# Altium schematic sheet Microcontroller.SchDoc
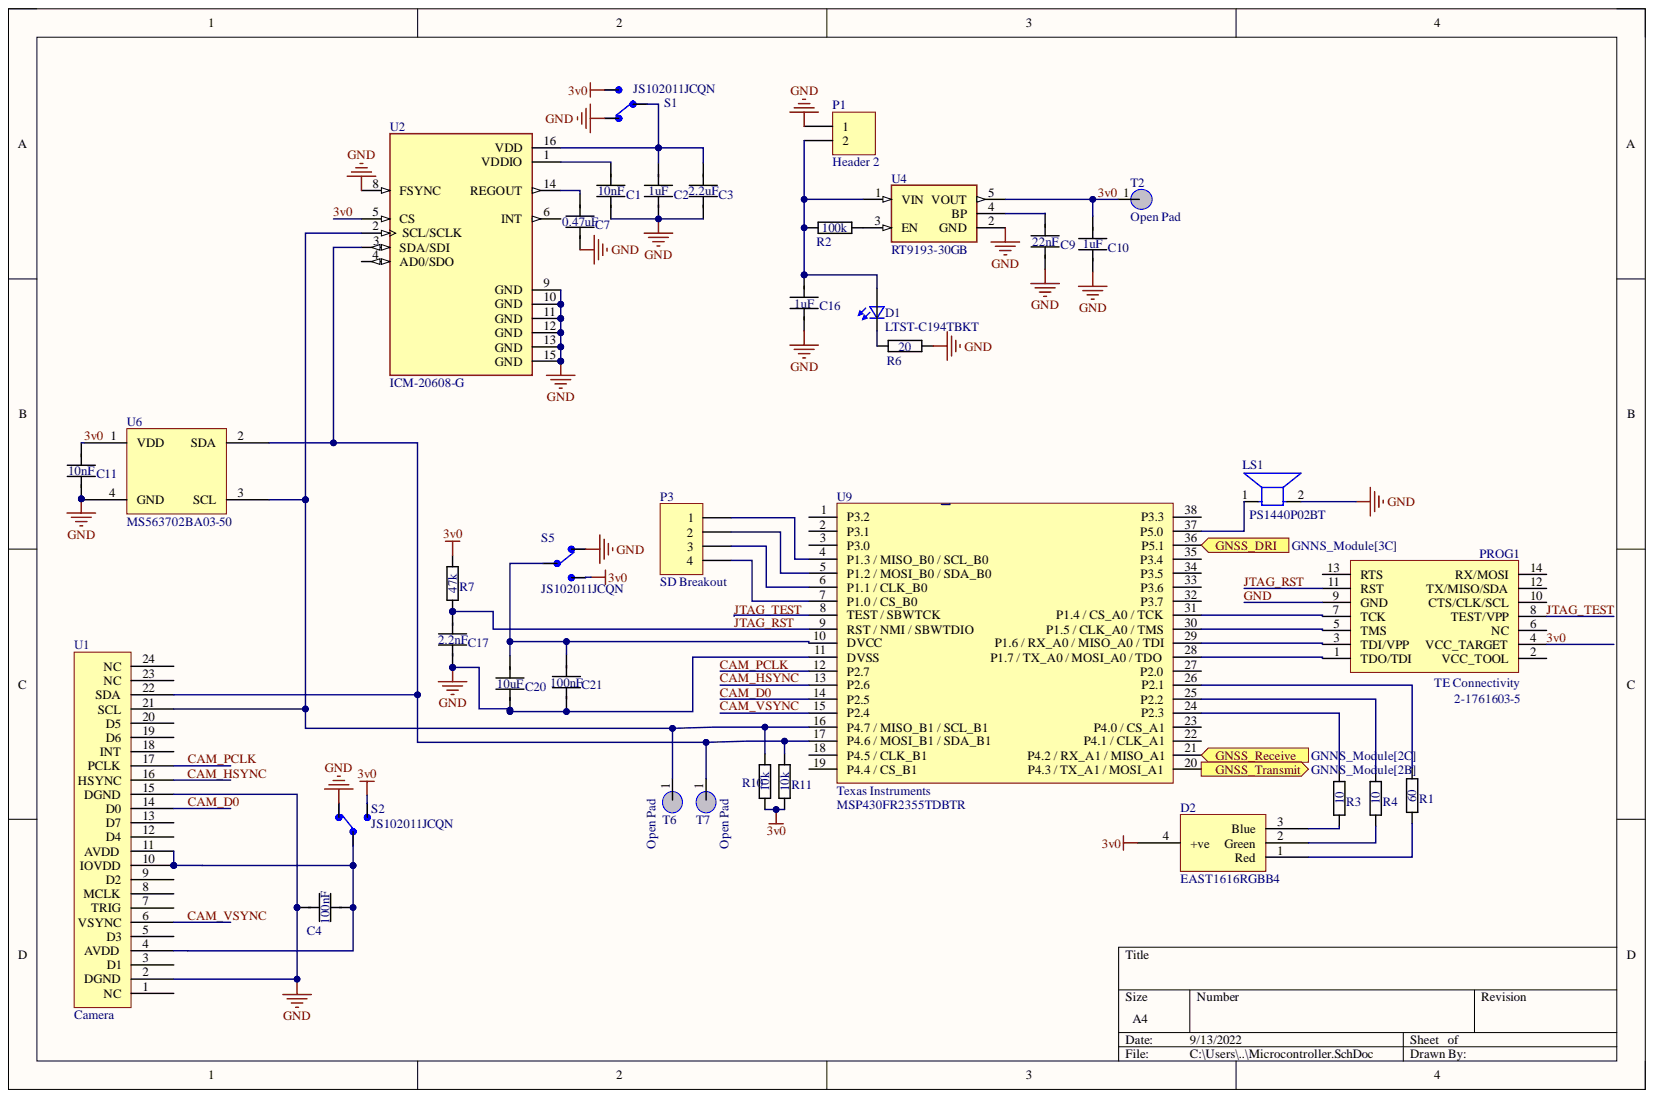
[diagram p0: Microcontroller.SchDoc]

## Parts
C1  value 10nF  [0603 (Capacitor)]  (hidden comment: C-n-010.000-5-0603)
C2  value 1uF  [0603 (Capacitor)]  (hidden comment: C-n-001.000-5-0603)
C3  C-n-001.000-5-0603  [0603 (Capacitor)]  (value not drawn)
C4  value 100nF  [0603 (Capacitor)]  (hidden comment: C-n-100.000-10-0603)
C7  C-n-010.000-5-0603  [0603 (Capacitor)]  (value not drawn)
C9  value 22nF  [0603 (Capacitor)]  (hidden comment: C-n-001.000-5-0603)
C10  value 1uF  [0603 (Capacitor)]  (hidden comment: C-n-001.000-5-0603)
C11  value 10nF  [0603 (Capacitor)]  (hidden comment: C-n-010.000-5-0603)
C16  value 1uF  [0603 (Capacitor)]  (hidden comment: C-n-001.000-5-0603)
C17  C-n-002.200-5-0603  [0603 (Capacitor)]  (value not drawn)
C20  value 10uF  [0603 (Capacitor)]  (hidden comment: C-n-001.000-5-0603)
C21  C-n-100.000-10-0603  [0603 (Capacitor)]  (value not drawn)
D1  LTST-C194TBKT  [0603]
D2  EAST1616RGBB4  [EAST1616RGBB4 - duplicate1]
LS1  PS1440P02BT  [BUZZER_PS1440P02BT]
P1  Header 2  [Battery Connector- 620102131822]
P3  SD Breakout  [HDR1X4]
PROG1  Manufacturer: TE Connectivity  Manufucturer PartNo: 2-1761603-5  [TE 2-1761603-5]  (hidden comment: MSP430 Programming Header)
R1  value 60  [0603 (Resistor)]  (hidden comment: R-k-010.000-1-0603)
R2  value 100k  [0603 (Resistor)]  (hidden comment: R-k-100.000-1-0603)
R3  value 10  [0603 (Resistor)]  (hidden comment: R-k-010.000-1-0603)
R4  value 10  [0603 (Resistor)]  (hidden comment: R-k-010.000-1-0603)
R6  value 20  [0603 (Resistor)]  (hidden comment: R-k-001.500-1-0603)
R7  value 47k  [0603 (Resistor)]  (hidden comment: R-k-047.000-1-0603)
R10  value 10k  [0603 (Resistor)]  (hidden comment: R-k-010.000-1-0603)
R11  value 10k  [0603 (Resistor)]  (hidden comment: R-k-010.000-1-0603)
S1  JS102011JCQN  [SW_JS102011JCQN]
S2  JS102011JCQN  [SW_JS102011JCQN]
S5  JS102011JCQN  [SW_JS102011JCQN]
T2  Open Pad  [Open Pad]
T6  Open Pad  [Open Pad]
T7  Open Pad  [Open Pad]
U1  Camera  [SFV24R-2STE1HLF]
U2  ICM-20608-G  [ICM-20608-G]
U4  RT9193-30GB  [RT9193-33GB]
U6  MS563702BA03-50  [MS5837-30BA]
U9  Manufacturer: Texas Instruments  Manufacturer Part Number: MSP430FR2355TDBTR  [MSP430FR2355 38-TFSOP]  (hidden comment: MSP430FR2355 38-TFSOP)

## Ports
GNSS_DRI, GNSS_Receive, GNSS_Transmit

## Nets
3v0: C10.1 C11.1 D2.4(+ve) PROG1.4(VCC_TARGET) R7.1 R10.2 R11.2 S1.3 S2.3 S5.3 T2.1 U2.5(CS) U4.5(VOUT) U6.1(VDD)
CAM_D0: U1.14(D0) U9.14(P2.5)
CAM_HSYNC: U1.16(HSYNC) U9.13(P2.6)
CAM_PCLK: U1.17(PCLK) U9.12(P2.7)
CAM_VSYNC: U1.6(VSYNC) U9.15(P2.4)
GND: C1.2 C2.2 C3.2 C4.1 C7.2 C9.2 C10.2 C11.2 C16.2 C17.2 C20.2 C21.2 LS1.2 P1.1 PROG1.9(GND) R6.1 S1.1 S2.1 S5.1 U1.2(DGND) U1.15(DGND) U2.8(FSYNC) U2.9(GND) U2.10(GND) U2.11(GND) U2.12(GND) U2.13(GND) U2.15(GND) U4.2(GND) U6.4(GND) U9.11(DVSS)
GNSS_DRI: U9.36(P5.1) ; port GNSS_DRI
GNSS_Receive: U9.21(P4.2_/_RX_A1_/_MISO_A1) ; port GNSS_Receive
GNSS_Transmit: U9.20(P4.3_/_TX_A1_/_MOSI_A1) ; port GNSS_Transmit
JTAG_RST: C17.1 PROG1.11(RST) R7.2 U9.9(RST_/_NMI_/_SBWTDIO)
JTAG_TEST: PROG1.8(TEST/VPP) U9.8(TEST_/_SBWTCK)
NetC1_1: C1.1 U2.1(VDDIO)
NetC2_1: C2.1 C3.1 S1.2 U2.16(VDD)
NetC4_2: C4.2 S2.2 U1.4(AVDD) U1.10(IOVDD) U1.11(AVDD)
NetC7_1: C7.1 U2.14(REGOUT)
NetC9_1: C9.1 U4.4(BP)
NetC16_1: C16.1 D1.2 P1.2 R2.2 U4.1(VIN)
NetC20_1: C20.1 C21.1 S5.2 U9.10(DVCC)
NetD1_1: D1.1 R6.2
NetD2_1: D2.1(Red) R1.2
NetD2_2: D2.2(Green) R4.2
NetD2_3: D2.3(Blue) R3.2
NetLS1_1: LS1.1 U9.37(P5.0)
NetP3_1: P3.1 U9.4(P1.3_/_MISO_B0_/_SCL_B0)
NetP3_2: P3.2 U9.5(P1.2_/_MOSI_B0_/_SDA_B0)
NetP3_3: P3.3 U9.6(P1.1_/_CLK_B0)
NetP3_4: P3.4 U9.7(P1.0_/_CS_B0)
NetPROG1_1: PROG1.1(TDO/TDI) U9.28
NetPROG1_3: PROG1.3(TDI/VPP) U9.29(P1.6_/_RX_A0_/_MISO_A0_/_TDI)
NetPROG1_5: PROG1.5(TMS) U9.30(P1.5_/_CLK_A0_/_TMS)
NetPROG1_7: PROG1.7(TCK) U9.31(P1.4_/_CS_A0_/_TCK)
NetR1_1: R1.1 U9.26(P2.1)
NetR2_1: R2.1 U4.3(EN)
NetR3_1: R3.1 U9.24(P2.3)
NetR4_1: R4.1 U9.25(P2.2)
NetR10_1: R10.1 T6.1 U1.21(SCL) U2.2(SCL/SCLK) U6.3(SCL) U9.16(P4.7_/_MISO_B1_/_SCL_B1)
NetR11_1: R11.1 T7.1 U1.22(SDA) U2.3(SDA/SDI) U6.2(SDA) U9.17(P4.6_/_MOSI_B1_/_SDA_B1)

Not connected: PROG1 2(VCC_TOOL) 6(NC) 10(CTS/CLK/SCL) 12(TX/MISO/SDA) 13(RTS) 14(RX/MOSI); U1 1(NC) 3(D1) 5(D3) 7(TRIG) 8(MCLK) 9(D2) 12(D4) 13(D7) 18(INT) 19(D6) 20(D5) 23(NC) 24(NC); U2 4(AD0/SDO) 6(INT); U9 1(P3.2) 2(P3.1) 3(P3.0) 18(P4.5_/_CLK_B1) 19(P4.4_/_CS_B1) 22(P4.1_/_CLK_A1) 23(P4.0_/_CS_A1) 27(P2.0) 32(P3.7) 33(P3.6) 34(P3.5) 35(P3.4) 38(P3.3)

## Library data of the parts
C1 C11 = C-n-010.000-5-0603: Capacitor 10nF 0603 +/-5%; Manufacturer: Murata; Manufacturer PartNo: GRM1885C1H103JA01D; Case/Package: 0603; Component Kind: Standard; Library Name: Passives.SchLib; Tolerance: +/-5%
C2 C10 C16 = C-n-001.000-5-0603: Capacitor 1uF 0603 +/-5%; Manufacturer: Murata; Manufacturer PartNo: GCM1885C2A102JA16D; Case/Package: 0603; Component Kind: Standard; Library Name: Passives.SchLib; Tolerance: +/-5%
C3 = C-n-001.000-5-0603: Capacitor 2,2uF 0603 +/-5%; Manufacturer: Murata; Manufacturer PartNo: GCM1885C2A102JA16D; Value: 2.2uF; Case/Package: 0603; Component Kind: Standard; Library Name: Passives.SchLib; Tolerance: +/-5%
C4 C21 = C-n-100.000-10-0603: Capacitor 100nF 0603 +/-10%; Manufacturer: Murata; Manufacturer PartNo: GRM188R72A104KA35D; Case/Package: 0603; Component Kind: Standard; Library Name: Passives.SchLib; Tolerance: +/-10%
  C21: Value: 100nF
C7 = C-n-010.000-5-0603: Capacitor 0.47uF 0603 +/-5%; Manufacturer: Murata; Manufacturer PartNo: GRM1885C1H103JA01D; Case/Package: 0603; Component Kind: Standard; Library Name: Passives.SchLib; Tolerance: +/-5%; Value: 0.47uF
C9 = C-n-001.000-5-0603: Capacitor 22nF 0603 +/-5%; Manufacturer: Murata; Manufacturer PartNo: GCM1885C2A102JA16D; Case/Package: 0603; Component Kind: Standard; Library Name: Passives.SchLib; Tolerance: +/-5%
C17 = C-n-001.000-5-0603: Capacitor 2.2nF 0603 +/-5%; Manufacturer: Murata; Manufacturer PartNo: GCM1885C2A102JA16D; Case/Package: 0603; Component Kind: Standard; Library Name: Passives.SchLib; Tolerance: +/-5%; Value: 2.2nF
C20 = C-n-001.000-5-0603: Capacitor 10uF 0603 +/-5%; Manufacturer: Murata; Manufacturer PartNo: GCM1885C2A102JA16D; Case/Package: 0603; Component Kind: Standard; Library Name: Passives.SchLib; Tolerance: +/-5%
D1 = LTST-C194TBKT: Blue 468nm LED Indication - Discrete 3.3V 0603 _1608 Metric_; MF: Lite-On Inc.; Component Kind: Standard; Digi-Key_Part_Number: 160-1837-1-ND; Digi-Key_Purchase_URL: https://www.digikey.com/product-detail/en/lite-on-inc/LTST-C194TBKT/160-1837-1-ND/2356236?utm_source=snapeda&utm_medium=aggregator&utm_campaign=symbol; Library Name: SnapEDAsch.SchLib; MP: LTST-C194TBKT; Package: 0603 Lite-On; SnapEDA_Link: https://www.snapeda.com/parts/LTST-C194TBKT/Lite-On%20Inc./view-part/426592/?ref=snap
LS1 = PS1440P02BT: Buzzers Transducer, Externally Driven Piezo 3V 4kHz 75dB @ 3V, 10cm Through Hole PC Pins; MF: TDK; Component Kind: Standard; Digi-Key_Part_Number: 445-5242-1-ND; Digi-Key_Purchase_URL: https://www.digikey.com/product-detail/en/tdk-corporation/PS1440P02BT/445-5242-1-ND/2236832?utm_source=snapeda&utm_medium=aggregator&utm_campaign=symbol; Library Name: Schlib.SchLib; MP: PS1440P02BT; Package: SOP-8 TDK; SnapEDA_Link: https://www.snapeda.com/parts/PS1440P02BT/TDK/view-part/295705/?ref=snap
P1 = Header 2: Header, 2-Pin; Component Kind: Standard; LatestRevisionDate: 17-Jul-2002; LatestRevisionNote: Re-released for DXP Platform.; Library Name: Miscellaneous Connectors.SchLib; Signal Integrity: Connector; Publisher: Altium Limited
P3 = Header 4: Header, 4-Pin; Component Kind: Standard; LatestRevisionDate: 17-Jul-2002; LatestRevisionNote: Re-released for DXP Platform.; Library Name: Miscellaneous Connectors.IntLib; Signal Integrity: Connector; Publisher: Altium Limited
PROG1 = MSP430 Programming Header: 14 Pin JTAG Header for MSP430 Debug Probe; Component Kind: Standard; Library Name: Ti MSP430.SchLib
R1 = R-k-010.000-1-0603: Resistor 60 0603 +/-1%; Manufacturer: Vishay Dale; Manufacturer PartNo: CRCW060310K0FKEAHP; Case/Package: 0603; Component Kind: Standard; Library Name: Passives.SchLib; Tolerance: +/-1%
R2 = R-k-100.000-1-0603: Resistor 100k 0603 +/-1%; Manufacturer: Vishay Dale; Manufacturer PartNo: CRCW0603100KFKEAHP; Case/Package: 0603; Component Kind: Standard; Library Name: Passives.SchLib; Tolerance: +/-1%
R3 R4 = R-k-010.000-1-0603: Resistor 10 0603 +/-1%; Manufacturer: Vishay Dale; Manufacturer PartNo: CRCW060310K0FKEAHP; Case/Package: 0603; Component Kind: Standard; Library Name: Passives.SchLib; Tolerance: +/-1%
R6 = R-k-001.500-1-0603: Resistor 20 0603 +/-1%; Manufacturer: Vishay Dale; Manufacturer PartNo: CRCW06031K50FKEAHP; Case/Package: 0603; Component Kind: Standard; Library Name: Passives.SchLib; Tolerance: +/-1%
R7 = R-k-068.000-1-0603: Resistor 47k 0603 +/-1%; Manufacturer: Vishay Dale; Manufacturer PartNo: CRCW060368K0FKEAHP; Case/Package: 0603; Component Kind: Standard; Library Name: Passives.SchLib; Tolerance: +/-1%
R10 R11 = R-k-010.000-1-0603: Resistor 10k 0603 +/-1%; Manufacturer: Vishay Dale; Manufacturer PartNo: CRCW060310K0FKEAHP; Case/Package: 0603; Component Kind: Standard; Library Name: Passives.SchLib; Tolerance: +/-1%
S1 S2 S5 = JS102011JCQN: Switch Slide Spdt 300ma 6v; Availability: Unavailable; Component Kind: Standard; Library Name: JS102011JCQN.SchLib; MP: JS102011JCQN; Package: None; Price: None
U2 = ICM-20608-G: Accelerometer, Gyroscope, 6 Axis Sensor IÂ²C, SPI Output; MF: TDK InvenSense; Availability: Good; Component Kind: Standard; Library Name: ICM-20608-G.SchLib; MP: ICM-20608-G; Package: LGA-16 InvenSense; Price: None; Purchase-URL: https://pricing.snapeda.com/search/part/ICM-20608-G/?ref=eda; SnapEDA_Link: https://www.snapeda.com/parts/ICM-20608-G/TDK%20InvenSense/view-part/196551/?ref=snap
U4 = RT9193-33GB: Linear Voltage Regulator IC 1 Output 300mA SOT-23-5; MF: Richtek USA; Availability: Unavailable; Component Kind: Standard; Library Name: SnapEDAsch.SchLib; MP: RT9193-33GB; Package: SOT-23-5 Richtek; Price: None; SnapEDA_Link: https://www.snapeda.com/parts/RT9193-33GB/Richtek%20USA%20Inc./view-part/55570/?ref=snap
U6 = MS5837-02BA21: Pressure Sensor, Absolute, 2Bar, I2C; Manufacturer: TE Connectivity; Max Pressure: 145.04PSI; Operating Pressure: 17.4PSI; Port Style: No Port; Case/Package: SMD/SMT; Component Kind: Standard; ComponentLink1Description: https://www.te.com/commerce/DocumentDelivery/DDEController?Action=srchrtrv&amp;DocNm=MS5837-02BA01&amp;DocType=DS&amp;DocLang=English&src-supplier=Digi-Key; ComponentLink1URL: https://www.te.com/commerce/DocumentDelivery/DDEController?Action=srchrtrv&amp;DocNm=MS5837-02BA01&amp;DocType=DS&amp;DocLang=English&src-supplier=Digi-Key; Library Name: Schlib.SchLib; Manufacturer Part Number: MS5837-02BA21; Max Operating Temperature: 85°C; Min Operating Temperature: -20°C; Output Type: I2C; Pressure Type: Absolute; Pricing 1: 1=12.98000, 5=11.38800, 10=10.24900, 25=9.11040, 50=8.42700, 100=7.28820, 500=6.83264, 1000=6.37714 (USD); RoHS: No; RoHS Compliant: Yes; Stock 1: 5173; Supplier 1: Digi-Key; Termination: SMD/SMT
U9 = MSP430FR2355 38-TFSOP: MSP430 Microcontroller; Component Kind: Standard; Library Name: Ti MSP430.SchLib
D2 = EAST1616RGBB4: Component Kind: Standard; Library Name: Schlib.SchLib
T2 T6 T7 = Open Pad: Component Kind: Standard; Library Name: Schlib.SchLib
U1 = Camera: Component Kind: Standard; Library Name: Schlib.SchLib
